# Altium schematic sheet Sway Rev C - Sheet 1.SchDoc("Sway Rev C - Sheet 1")
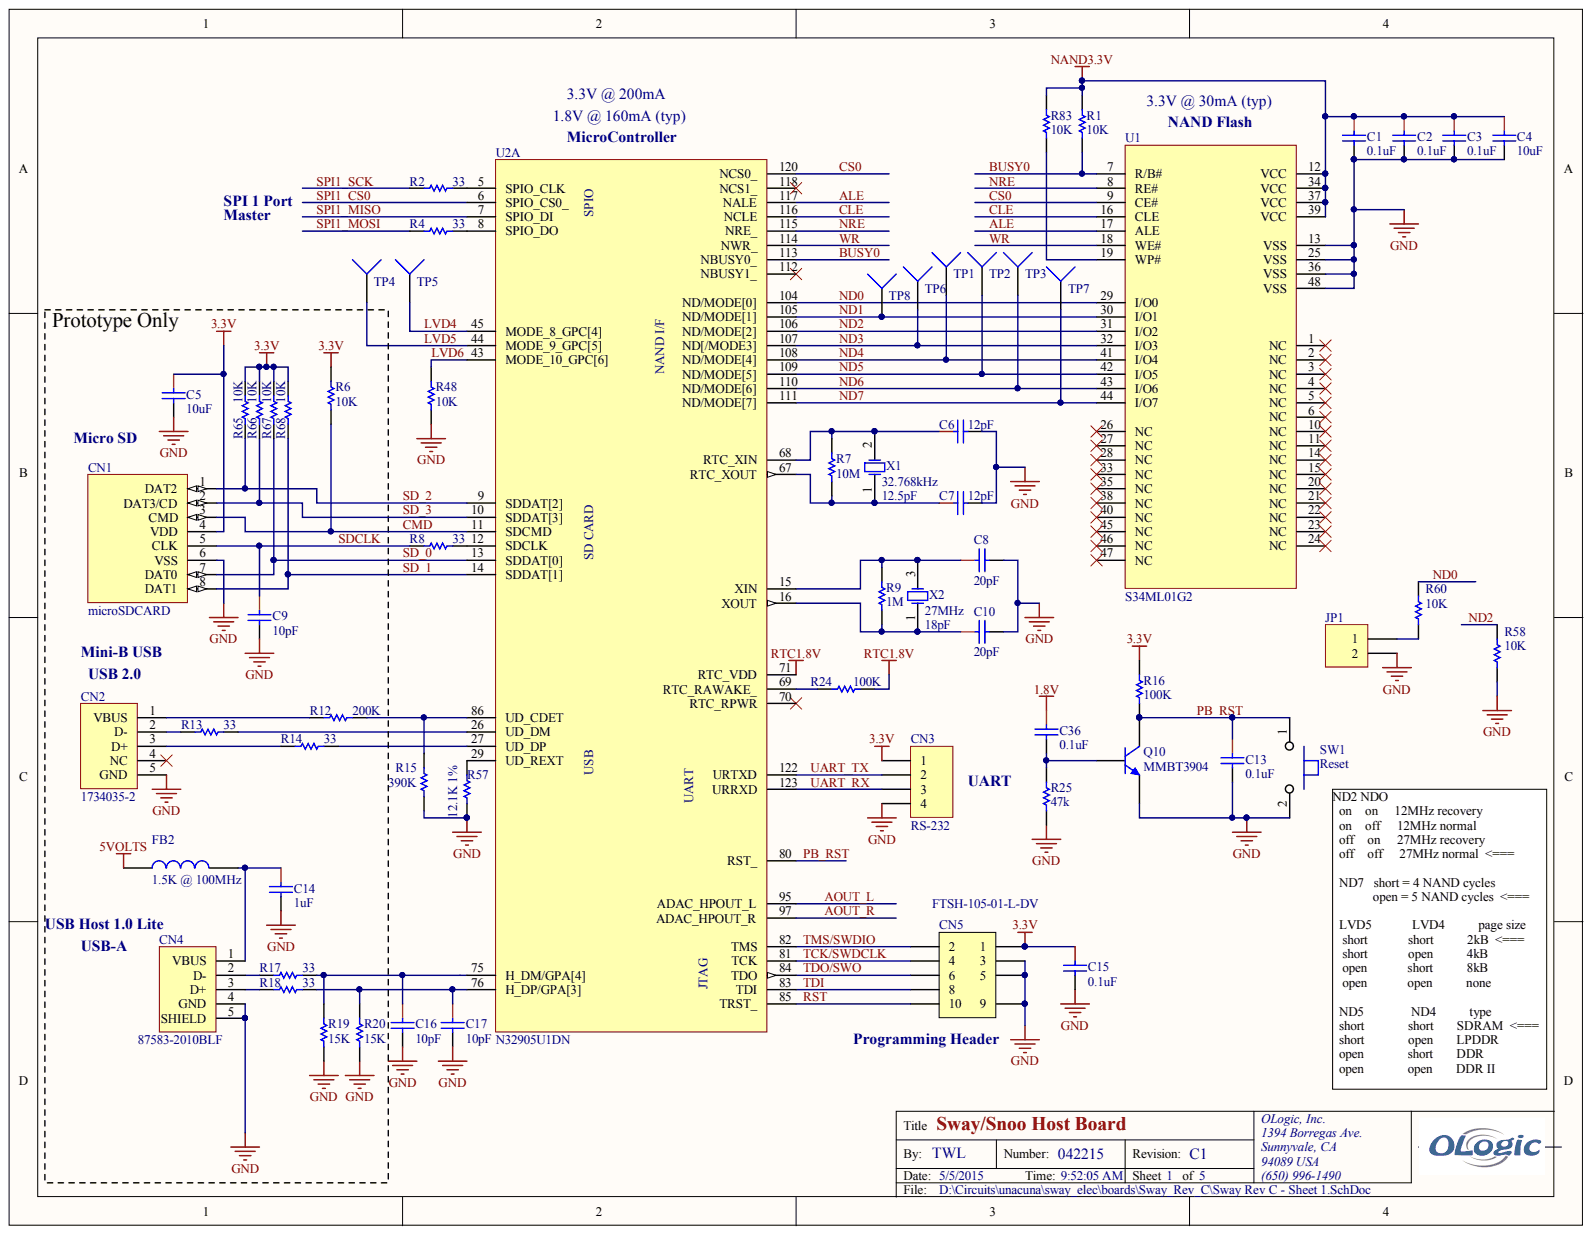
[diagram p0: Sway Rev C - Sheet 1.SchDoc]

## Parts
C1  0.1uF  [CAPC0603(1608)_L]
C2  0.1uF  [CAPC0603(1608)_L]
C3  0.1uF  [CAPC0603(1608)_L]
C4  10uF  [CAPC0805(2012)_L]
C5  10uF  [CAPC0805(2012)_L]
C6  12pF  [CAPC0603(1608)_L]
C7  12pF  [CAPC0603(1608)_L]
C8  20pF  [CAPC0603(1608)_L]
C9  10pF  [CAPC0603(1608)_L]
C10  20pF  [CAPC0603(1608)_L]
C13  0.1uF  [CAPC0603(1608)_L]
C14  1uF  [CAPC0603(1608)_L]
C15  0.1uF  [CAPC0603(1608)_L]
C16  10pF  [CAPC0603(1608)_L]
C17  10pF  [CAPC0603(1608)_L]
C36  0.1uF  [CAPC0603(1608)_L]
CN1  microSDCARD  [MicroSD]
CN2  1734035-2  Specifications: USB 2.0  [USB-MINI-B]
CN3  RS-232  [HDR1X4]
CN4  87583-2010BLF  [87583-2010]
CN5  Manufacturer Part Number: FTSH-105-01-L-DV  [HDR_2x5-SMD-1.27mm-SWD]  (hidden comment: Conn-2x5)
FB2  1.5K @ 100MHz  [RESC0603(1608)_L]
JP1  PGM  [HDR1X2]  (value not drawn)
Q10  MMBT3904  [SOT23]
R1  10K  [RESC0603(1608)_L]
R2  33  [RESC0603(1608)_L]
R4  33  [RESC0603(1608)_L]
R6  10K  [RESC0603(1608)_L]
R7  10M  [RESC0603(1608)_L]
R8  33  [RESC0603(1608)_L]
R9  1M  [RESC0603(1608)_L]
R12  200K  [RESC0603(1608)_L]
R13  33  [RESC0603(1608)_L]
R14  33  [RESC0603(1608)_L]
R15  390K  [RESC0603(1608)_L]
R16  100K  [RESC0603(1608)_L]
R17  33  [RESC0603(1608)_L]
R18  33  [RESC0603(1608)_L]
R19  15K  [RESC0603(1608)_L]
R20  15K  [RESC0603(1608)_L]
R24  100K  [RESC0603(1608)_L]
R25  47k  [RESC0603(1608)_N]
R48  10K  [RESC0603(1608)_L]
R57  12.1K 1%  [RESC0603(1608)_L]
R58  10K  [RESC0603(1608)_L]
R60  10K  [RESC0603(1608)_L]
R65  10K  [RESC0603(1608)_L]
R66  10K  [RESC0603(1608)_L]
R67  10K  [RESC0603(1608)_L]
R68  10K  [RESC0603(1608)_L]
R83  10K  [RESC0603(1608)_L]
SW1  Reset  [EVPA-PushButton]
TP1  Test Point  [TestPoint-SM]  (value not drawn)
TP2  Test Point  [TestPoint-SM]  (value not drawn)
TP3  Test Point  [TestPoint-SM]  (value not drawn)
TP4  Test Point  [TestPoint-SM]  (value not drawn)
TP5  Test Point  [TestPoint-SM]  (value not drawn)
TP6  Test Point  [TestPoint-SM]  (value not drawn)
TP7  Test Point  [TestPoint-SM]  (value not drawn)
TP8  Test Point  [TestPoint-SM]  (value not drawn)
U1  S34ML01G2  [TSOP48]
U2  N32905U1DN  [LQFP128]  (part A)
X1  32.768kHz  Load Capacitance: 12.5pF  [FC-135]
X2  27MHz  Load Capacitance: 18pF  [SMOSC/6x3.6]

## Nets
1.8V: C36.1
3.3V: C5.1 C15.1 CN1.4(VDD) CN3.1 CN5.1 R6.2 R16.2 R65.2 R66.2 R67.2 R68.2
5VOLTS: FB2.1
GND: C1.2 C2.2 C3.2 C4.2 C5.2 C6.2 C7.2 C8.2 C9.2 C10.2 C13.2 C14.2 C15.2 C16.2 C17.2 CN1.6(VSS) CN2.5(GND) CN3.4 CN4.4(GND) CN4.5(SHIELD) CN5.3 CN5.5 CN5.9 JP1.2 Q10.2 R15.1 R19.1 R20.1 R25.1 R48.1 R57.1 R58.1 SW1.2 U1.13(VSS) U1.25(VSS) U1.36(VSS) U1.48(VSS)
NAND3.3V: C1.1 C2.1 C3.1 C4.1 R1.2 R83.2 U1.12(VCC) U1.34(VCC) U1.37(VCC) U1.39(VCC)
RTC1.8V: R24.1 U2.71(RTC_VDD)
ALE: U1.17(ALE) U2.117(NALE)
AOUT_L: U2.95(ADAC_HPOUT_L)
AOUT_R: U2.97(ADAC_HPOUT_R)
BUSY0: R1.1 U1.7(R/B#) U2.113(NBUSY0_)
CLE: U1.16(CLE) U2.116(NCLE)
CMD: CN1.3(CMD) R6.1 U2.11(SDCMD)
CS0: U1.9(CE#) U2.120(NCS0_)
LVD4: TP5.1 U2.45(MODE_8_GPC[4])
LVD5: TP4.1 U2.44(MODE_9_GPC[5])
LVD6: R48.2 U2.43(MODE_10_GPC[6])
ND0: R60.2 U1.29(I/O0) U2.104(ND/MODE[0])
ND1: TP8.1 U1.30(I/O1) U2.105(ND/MODE[1])
ND2: R58.2 U1.31(I/O2) U2.106(ND/MODE[2])
ND3: TP6.1 U1.32(I/O3) U2.107(ND[/MODE3])
ND4: TP1.1 U1.41(I/O4) U2.108(ND/MODE[4])
ND5: TP2.1 U1.42(I/O5) U2.109(ND/MODE[5])
ND6: TP3.1 U1.43(I/O6) U2.110(ND/MODE[6])
ND7: TP7.1 U1.44(I/O7) U2.111(ND/MODE[7])
NRE: U1.8(RE#) U2.115(NRE_)
PB_RST: C13.1 Q10.3 R16.1 SW1.1 U2.80(RST_)
RST: CN5.10 U2.85(TRST_)
SD_0: CN1.7(DAT0) R67.1 U2.13(SDDAT[0])
SD_1: CN1.8(DAT1) R68.1 U2.14(SDDAT[1])
SD_2: CN1.1(DAT2) R65.1 U2.9(SDDAT[2])
SD_3: CN1.2(DAT3/CD) R66.1 U2.10(SDDAT[3])
SDCLK: C9.1 CN1.5(CLK) R8.2
SPI1_CS0: U2.6(SPIO_CS0_)
SPI1_MISO: U2.7(SPIO_DI)
SPI1_MOSI: R4.2
SPI1_SCK: R2.2
TCK/SWDCLK: CN5.4 U2.81(TCK)
TDI: CN5.8 U2.83(TDI)
TDO/SWO: CN5.6 U2.84(TDO)
TMS/SWDIO: CN5.2 U2.82(TMS)
UART_RX: CN3.3 U2.123(URRXD)
UART_TX: CN3.2 U2.122(URTXD)
WR: U1.18(WE#) U2.114(NWR_)
NetC6_1: C6.1 R7.2 U2.68(RTC_XIN) X1.2
NetC7_1: C7.1 R7.1 U2.67(RTC_XOUT) X1.1
NetC8_1: C8.1 R9.2 U2.15(XIN) X2.3
NetC10_1: C10.1 R9.1 U2.16(XOUT) X2.1
NetC14_1: C14.1 CN4.1(VBUS) FB2.2
NetC16_1: C16.1 R17.1 R19.2 U2.75(H_DM/GPA[4])
NetC17_1: C17.1 R18.1 R20.2 U2.76(H_DP/GPA[3])
NetC36_2: C36.2 Q10.1 R25.2
NetCN2_1: CN2.1(VBUS) R12.2
NetCN2_2: CN2.2(D-) R13.2
NetCN2_3: CN2.3(D+) R14.2
NetCN4_2: CN4.2(D-) R17.2
NetCN4_3: CN4.3(D+) R18.2
NetJP1_1: JP1.1 R60.1
NetR2_1: R2.1 U2.5(SPIO_CLK)
NetR4_1: R4.1 U2.8(SPIO_DO)
NetR8_1: R8.1 U2.12(SDCLK)
NetR12_1: R12.1 R15.2 U2.86(UD_CDET)
NetR13_1: R13.1 U2.26(UD_DM)
NetR14_1: R14.1 U2.27(UD_DP)
NetR24_2: R24.2 U2.69(RTC_RAWAKE_)
NetR57_2: R57.2 U2.29(UD_REXT)
NetR83_1: R83.1 U1.19(WP#)

Not connected: CN2 4(NC); U1 1(NC) 2(NC) 3(NC) 4(NC) 5(NC) 6(NC) 10(NC) 11(NC) 14(NC) 15(NC) 20(NC) 21(NC) 22(NC) 23(NC) 24(NC) 26(NC) 27(NC) 28(NC) 33(NC) 35(NC) 38(NC) 40(NC) 45(NC) 46(NC) 47(NC); U2 70(RTC_RPWR) 112(NBUSY1_) 118(NCS1_)

## Library data of the parts
C1-C3 C13 C36 = CAP CER 0.1UF 16V 10% X7R 0603: 0.1uF 0603; Manufacturer: Kemet; Manufacturer Part Number: C0603C104K4RACTU; Capacitance: 0.1µF; Temperature Coefficient: X7R; Tolerance: ±10%; Voltage - Rated: 16V; Applications: General Purpose; Category: Capacitors; ClassName: UP; Component Kind: Standard; ComponentLink1Description: http://www.kemet.com/datasheets&C0603C104K4RACTU; ComponentLink1URL: http://www.kemet.com/datasheets&C0603C104K4RACTU; Features: -; Height - Seated (Max): -; Lead Spacing: -; Lead Style: -; Library Name: OLogic-Passive.IntLib; Mounting Type: Surface Mount, MLCC; Operating Temperature: -55°C ~ 125°C; Package / Case: 0603 (1608 Metric); Packaging: Tape & Reel (TR); Pricing 1: 4000=0.00414, 8000=0.00378, 12000=0.0036, 28000=0.00342, 100000=0.00248 (USD); Ratings: -; RoHS: RoHS Compliant; Size / Dimension: 0.063" L x 0.031" W (1.60mm x 0.80mm); Stock 1: 2360000; Supplier 1: Digi-Key; Supplier Part Number 1: 399-1096-2-ND; Thickness (Max): 0.034" (0.87mm)
C4 C5 = CAP CER 10UF 10V Y5V 0805: 10uF 0805; Manufacturer: Taiyo Yuden; Manufacturer Part Number: LMK212F106ZG-T; Capacitance: 10µF; Temperature Coefficient: Y5V (F); Tolerance: -20%, +80%; Voltage - Rated: 10V; Applications: General Purpose; Category: Capacitors; Component Kind: Standard; ComponentLink1Description: http://www.yuden.co.jp/or/product/category/capacitor/LMK212F106ZG-T.pdf; ComponentLink1URL: http://www.yuden.co.jp/or/product/category/capacitor/LMK212F106ZG-T.pdf; Features: -; Height - Seated (Max): -; Lead Spacing: -; Lead Style: -; Library Name: OLogic-Passive.IntLib; Mounting Type: Surface Mount, MLCC; Operating Temperature: -30°C ~ 85°C; Package / Case: 0805 (2012 Metric); Packaging: Cut Tape (CT); Pricing 1: 1=0.17, 10=0.115, 50=0.0662, 100=0.0546, 250=0.046, 500=0.03852, 1000=0.03163 (USD); Ratings: -; RoHS: RoHS Compliant; Size / Dimension: 0.079" L x 0.049" W (2.00mm x 1.25mm); Stock 1: 194456; Supplier 1: Digi-Key; Supplier Part Number 1: 587-1312-1-ND; Thickness (Max): 0.053" (1.35mm)
  C4: ClassName: UP
  C5: ClassName: uSDCard
C6 C7 = CAP CER 12PF 50V 5% NP0 0603: 12pF 0603 50V; Manufacturer: TDK Corporation; Manufacturer Part Number: C1608C0G1H120J080AA; Temperature Coefficient: C0G, NP0; Tolerance: ±5%; Applications: General Purpose; Capacitance: 12pF; Category: Capacitors; Component Kind: Standard; ComponentLink1Description: http://product.tdk.com/capacitor/mlcc/en/documents/mlcc_commercial_general_en.pdf; ComponentLink1URL: http://product.tdk.com/capacitor/mlcc/en/documents/mlcc_commercial_general_en.pdf; Features: -; Height - Seated (Max): -; Lead Spacing: -; Lead Style: -; Library Name: OLogic-Passive.IntLib; Mounting Type: Surface Mount, MLCC; Operating Temperature: -55°C ~ 125°C; Package / Case: 0603 (1608 Metric); Packaging: Cut Tape (CT); Pricing 1: 1=0.1, 10=0.028, 100=0.0129, 250=0.01056, 500=0.00904, 1000=0.0069 (USD); Ratings: -; RoHS: RoHS Compliant; Size / Dimension: 0.063" L x 0.031" W (1.60mm x 0.80mm); Stock 1: 133676; Supplier 1: Digi-Key; Supplier Part Number 1: 445-1270-1-ND; Thickness (Max): 0.037" (0.95mm); Voltage - Rated: 50V
C8 C10 = CAP CER 20PF 50V 5% NP0 0603: 20pF 0603; Manufacturer: Murata Electronics North America; Manufacturer Part Number: GRM1885C1H200JA01D; Temperature Coefficient: C0G, NP0; Tolerance: ±5%; Voltage - Rated: 50V; Applications: General Purpose; Capacitance: 20pF; Category: Capacitors; ClassName: UP; Component Kind: Standard; ComponentLink1Description: http://search.murata.co.jp/Ceramy/image/img/A01X/G101/ENG/GRM1885C1H200JA01-01.pdf; ComponentLink1URL: http://search.murata.co.jp/Ceramy/image/img/A01X/G101/ENG/GRM1885C1H200JA01-01.pdf; Features: -; Height - Seated (Max): -; Lead Spacing: -; Lead Style: -; Library Name: OLogic-Passive.IntLib; Mounting Type: Surface Mount, MLCC; Operating Temperature: -55°C ~ 125°C; Package / Case: 0603 (1608 Metric); Packaging: Cut Tape (CT); Pricing 1: 1=0.1, 10=0.045, 50=0.0246, 100=0.0208, 250=0.01704, 500=0.01456, 1000=0.01113 (USD); Ratings: -; RoHS: RoHS Compliant; Size / Dimension: 0.063" L x 0.031" W (1.60mm x 0.80mm); Stock 1: 33890; Supplier 1: Digi-Key; Supplier Part Number 1: 490-1410-1-ND; Thickness (Max): 0.035" (0.90mm)
C9 C16 C17 = CAP CER 10PF 250V 2% NP0 0603: 10pF 0603; Manufacturer: Johanson Technology Inc; Manufacturer Part Number: 251R14S100GV4T; Temperature Coefficient: C0G, NP0; Tolerance: ±2%; Voltage - Rated: 250V; Applications: RF, Microwave, High Frequency; Capacitance: 10pF; Category: Capacitors; Component Kind: Standard; ComponentLink1Description: http://www.johansontechnology.com/images/stories/catalog/JTI_CAT_2012_MLCC_HighQ.pdf; ComponentLink1URL: http://www.johansontechnology.com/images/stories/catalog/JTI_CAT_2012_MLCC_HighQ.pdf; Features: High Q, Low Loss; Height - Seated (Max): -; Lead Spacing: -; Lead Style: -; Library Name: OLogic-Passive.IntLib; Mounting Type: Surface Mount, MLCC; Operating Temperature: -55°C ~ 125°C; Package / Case: 0603 (1608 Metric); Packaging: Cut Tape (CT); Pricing 1: 1=0.5, 10=0.441, 25=0.3152, 50=0.2678, 100=0.2205, 250=0.1764, 500=0.16066, 1000=0.1449 (USD); Ratings: -; RoHS: RoHS Compliant; Size / Dimension: 0.062" L x 0.032" W (1.57mm x 0.81mm); Stock 1: 34809; Supplier 1: Digi-Key; Supplier Part Number 1: 712-1307-1-ND; Thickness (Max): 0.035" (0.89mm)
  C9: ClassName: uSDCard
  C16 C17: ClassName: USB_A
C14 = CAP CER 1UF 10V 10% X5R 0603: 1uF 0603; Manufacturer: Kemet; Manufacturer Part Number: C0603C105K8PACTU; Capacitance: 1.0µF; Temperature Coefficient: X5R; Tolerance: ±10%; Voltage - Rated: 10V; Applications: General Purpose; Category: Capacitors; ClassName: USB_A; Component Kind: Standard; ComponentLink1Description: http://www.kemet.com/datasheets&C0603C105K8PACTU; ComponentLink1URL: http://www.kemet.com/datasheets&C0603C105K8PACTU; Features: -; Height - Seated (Max): -; Lead Spacing: -; Lead Style: -; Library Name: OLogic-Passive.IntLib; Mounting Type: Surface Mount, MLCC; Operating Temperature: -55°C ~ 85°C; Package / Case: 0603 (1608 Metric); Packaging: Cut Tape (CT); Pricing 1: 1=0.1, 10=0.043, 25=0.0304, 50=0.0232, 100=0.0196, 250=0.01608, 1000=0.0105 (USD); Ratings: -; RoHS: RoHS Compliant; Size / Dimension: 0.063" L x 0.031" W (1.60mm x 0.80mm); Stock 1: 1121198; Supplier 1: Digi-Key; Supplier Part Number 1: 399-3118-1-ND; Thickness (Max): 0.035" (0.90mm)
C15 = CAP CER 0.1UF 50V 10% X7R 0603: 0.1UF 50V 0603; Manufacturer: Kemet; Manufacturer Part Number: C0603C104K5RACTU; Capacitance: 0.1µF; Temperature Coefficient: X7R; Tolerance: ±10%; Applications: General Purpose; Category: Capacitors; ClassName: UP; Component Kind: Standard; ComponentLink1Description: http://www.kemet.com/docfinder?Partnumber=C0603C104K5RACTU; ComponentLink1URL: http://www.kemet.com/docfinder?Partnumber=C0603C104K5RACTU; Features: -; Height - Seated (Max): -; Lead Spacing: -; Lead Style: -; Library Name: OLogic-Passive.IntLib; Mounting Type: Surface Mount, MLCC; Operating Temperature: -55°C ~ 125°C; Package / Case: 0603 (1608 Metric); Packaging: Cut Tape (CT); Pricing 1: 1=0.1, 10=0.041, 50=0.0222, 100=0.0187, 250=0.01532, 500=0.0131, 1000=0.0102 (USD); Ratings: -; RoHS: RoHS Compliant; Size / Dimension: 0.063" L x 0.031" W (1.60mm x 0.80mm); Stock 1: 1397942; Supplier 1: Digi-Key; Supplier Part Number 1: 399-5089-1-ND; Thickness (Max): 0.034" (0.87mm); Voltage - Rated: 50V
CN1 = SDCARD_micro: micro SD Card Slot; Manufacturer: Molex Inc; Manufacturer Part Number: 0473521001; Card Type: Secure Digital - microSD™; Connector Type: Connector and Ejector; Insertion, Removal Method: Push In, Push Out; Number of Positions: 8 (6 + 2); Category: Connectors, Interconnects; ClassName: uSDCard; Component Kind: Standard; ComponentLink1Description: http://www.molex.com/pdm_docs/sd/473521001_sd.pdf; ComponentLink1URL: http://www.molex.com/pdm_docs/sd/473521001_sd.pdf; Contact Finish: Gold; Contact Finish Thickness: -; Ejector Side: -; Features: Switch; Height Above Board: 0.074" (1.88mm); Library Name: OLogic-Connectors.IntLib; Mounting Feature: Normal, Standard - Top; Mounting Type: Surface Mount, Right Angle; Packaging: Cut Tape (CT); Pricing 1: 1=3.76, 10=3.605, 25=3.3048, 50=3.1548, 100=3.0045, 250=2.62896 (USD); RoHS: RoHS Compliant; Stock 1: 954; Supplier 1: Digi-Key; Supplier Part Number 1: WM3290CT-ND
CN2 = Mini-USB-B: Mini-USB RA Type B; Manufacturer: Tyco; Connector Type: USB - mini B; Gender: Receptacle; Number of Contacts: 5; PN: A31727CT-ND; Vendor: Digikey; Category: Connectors, Interconnects; ClassName: USB_B; Component Kind: Standard; ComponentLink1Description: http://www.te.com/commerce/DocumentDelivery/DDEController?Action=srchrtrv&DocNm=1734035&DocType=Customer+Drawing&DocLang=English; ComponentLink1URL: http://www.te.com/commerce/DocumentDelivery/DDEController?Action=srchrtrv&DocNm=1734035&DocType=Customer+Drawing&DocLang=English; Features: Board Guide; Ingress Protection: -; Library Name: OLogic-Connectors.IntLib; Manufacturer Part Number: 1734035-2; Mounting Type: Surface Mount, Right Angle, Horizontal; MPN: 1734035-2; Packaging: Cut Tape (CT); Pricing 1: 1=1.76, 10=1.598, 25=1.5004, 50=1.435, 100=1.3698, 250=1.23932 (USD); RoHS: RoHS Compliant; Stock 1: 27347; Supplier 1: Digi-Key; Supplier Part Number 1: A31727CT-ND
CN3 = Header 4: Header, 4-Pin; Manufacturer Part Number: 826936-4; Brand: TE Connectivity / AMP; Mating Post Length: 5.8 mm; Mounting Angle: Vertical; Number of Positions: 4; Pitch: 2.54 mm; Category: Headers & Wire Housings; ClassName: UP; Component Kind: Standard; ComponentLink1Description: http://www.te.com/catalog/pn/en/826936-4; ComponentLink1URL: http://www.te.com/catalog/pn/en/826936-4; Contact Gender: Pin (Male); Contact Material: Brass; Contact Plating: Tin; Flammability Rating: UL 94 V-0; Housing Material: Thermoplastic; Library Name: OLogic-Connectors.IntLib; Manufacturer: TE Connectivity / AMP; Minimum Order Quantity: 1; Mounting Style: -; Number of Rows: 1; Pricing 1: 1=0.250, 25=0.239, 50=0.233, 100=0.216, 250=0.206, 500=0.178, 1000=0.160, 2000=0.154, 5000=0.146 (USD); Product Category: AMPMODU Breakaway Headers; Product Type: Headers; Reeling: False; RoHS: RoHS Compliant; RoHS - Mouser: Y; Row Spacing: 2.54 mm; Series: AMPMODU MOD II; Signal Integrity: Connector; Standard Pack Qty: 1500; Stock 1: 1165; Supplier 1: Mouser; Supplier Part Number 1: 571-826936-4; Termination Post Length: 3.2 mm; Termination Style: Through Hole; Tradename: AMPMODU; Type: Breakaway
CN4 = FCI-87583-2010: USB-A Receptacle; Manufacturer: FCI; Number of Contacts: 4; PN: 609-4413-ND; Specifications: USB 2.0; Vendor: Digikey; Category: Connectors, Interconnects; Component Kind: Standard; ComponentLink1Description: http://portal.fciconnect.com/Comergent//fci/drawing/87583.pdf; ComponentLink1URL: http://portal.fciconnect.com/Comergent//fci/drawing/87583.pdf; Connector Type: USB - A; Features: Board Lock; Gender: Receptacle; Ingress Protection: -; Library Name: OLogic-Connectors.IntLib; Manufacturer Part Number: 87583-2010BLF; Mounting Type: Surface Mount, Right Angle, Horizontal; MPN: 87583-2010BLF; Packaging: Tube; Pricing 1: 1=0.89, 10=0.78, 25=0.7344, 50=0.7038, 100=0.6732, 250=0.612, 500=0.5508, 1000=0.4896, 2500=0.4437 (USD); RoHS: RoHS Compliant; Stock 1: 25474; Supplier 1: Digi-Key; Supplier Part Number 1: 609-4413-ND
CN5 = CONN-2x5-SWD: SAMTEC - FTSH-105-01-L-DV - HEADER, 1.27MM, SMD, 10WAY; Connector Type: Header, Unshrouded; Number of Positions: 10; Number of Positions Loaded: All; Pitch: 0.050" (1.27mm); PN: SAM1161-05-ND; Vendor: Digikey; Category: Connectors, Interconnects; ClassName: UP; Color: Black; Component Kind: Standard; ComponentLink1Description: http://www.samtec.com/documents/webfiles/cpdf/FTSH-1XX-XX-XXX-DV-XXX-MKT.pdf; ComponentLink1URL: http://www.samtec.com/documents/webfiles/cpdf/FTSH-1XX-XX-XXX-DV-XXX-MKT.pdf; Contact Finish: Gold; Contact Finish Thickness: 10µin (0.25µm); Contact Mating Length: 0.120" (3.05mm); Contact Type: Male Pin; Fastening Type: -; Features: -; Library Name: OLogic-Connectors.IntLib; Manufacturer: Samtec; Mounting Type: Surface Mount; MPN: FTSH-105-01-L-DV; Number of Rows: 2; Packaging: Bulk; Pricing 1: 1=3.42, 10=2.963, 100=2.5073, 500=1.99444, 1000=1.62404, 5000=1.48158, 10000=1.4246 (USD); RoHS: RoHS Compliant; Row Spacing: 0.050" (1.27mm); Stock 1: 0; Supplier 1: Digi-Key; Supplier Part Number 1: SAM1161-05-ND; Termination: Solder
FB2 = FERRITE CHIP 1500 OHMS 100MA 0603: 1.5K 0603 1500 100mA; Manufacturer: Laird-Signal Integrity Products; Manufacturer Part Number: HZ0603A152R-10; DC Resistance (DCR): 900 mOhm Max; Filter Type: Differential Mode - Single; Impedance @ Frequency: 1.5 kOhm @ 100MHz; Category: Filters; ClassName: USB_A; Component Kind: Standard; ComponentLink1Description: http://www.lairdtech.com/WorkArea/linkit.aspx?LinkIdentifier=id&ItemID=4872; ComponentLink1URL: http://www.lairdtech.com/WorkArea/linkit.aspx?LinkIdentifier=id&ItemID=4872; Current Rating: 100mA; Height (Max): 0.037" (0.95mm); Library Name: OLogic-Passive.IntLib; Mounting Type: Surface Mount; Package / Case: 0603 (1608 Metric); Packaging: Cut Tape (CT); Pricing 1: 1=0.1, 10=0.07, 25=0.0632, 50=0.057, 100=0.0417, 250=0.03036, 500=0.02784, 1000=0.02404 (USD); RoHS: RoHS Compliant; Size / Dimension: 0.063" L x 0.031" W (1.60mm x 0.80mm); Stock 1: 52189; Supplier 1: Digi-Key; Supplier Part Number 1: 240-2559-1-ND
JP1 = Header 2: Header, 2-Pin; Manufacturer Part Number: 826936-2; Brand: TE Connectivity; Mating Post Length: 5.8 mm; Mounting Angle: Vertical; Number of Positions: 2; Pitch: 2.54 mm; Category: Headers & Wire Housings; Component Kind: Standard; ComponentLink1Description: http://www.te.com/catalog/pn/en/826936-2; ComponentLink1URL: http://www.te.com/catalog/pn/en/826936-2; Contact Gender: Pin (Male); Contact Material: Brass; Contact Plating: Tin; Flammability Rating: UL 94 V-0; Housing Material: Thermoplastic; LatestRevisionNote: Re-released for DXP Platform.; Library Name: OLogic-Connectors.IntLib; Manufacturer: TE Connectivity; Minimum Order Quantity: 1; Mounting Style: -; Number of Rows: 1; Pricing 1: 1=0.100, 25=0.084, 50=0.080, 100=0.077, 500=0.070, 1000=0.067 (USD); Product Category: AMPMODU Breakaway Headers; Product Type: Headers; Reeling: False; RoHS: RoHS Compliant; RoHS - Mouser: Y; Row Spacing: 2.54 mm; Series: AMPMODU MOD II; Signal Integrity: Connector; Standard Pack Qty: 3000; Stock 1: 16740; Supplier 1: Mouser; Supplier Part Number 1: 571-826936-2; Termination Post Length: 3.2 mm; Termination Style: Through Hole; Tradename: AMPMODU; Type: Breakaway; Publisher: Altium Limited
Q10 = MMBT3904: NPN General Purpose Amplifier; Manufacturer: ON Semiconductor; Manufacturer Part Number: MMBT3904WT1G; Current - Collector (Ic) (Max): 200mA; DC Current Gain (hFE) (Min) @ Ic, Vce: 100 @ 10mA, 1V; Frequency - Transition: 300MHz; PN: MMBT3904WT1GOSCT-ND; Power - Max: 150mW; Vce Saturation (Max) @ Ib, Ic: 300mV @ 5mA, 50mA; Vendor: Digikey; Voltage - Collector Emitter Breakdown (Max): 40V; Category: Discrete Semiconductor Products; Component Kind: Standard; ComponentLink1Description: http://www.onsemi.com/pub_link/Collateral/MMBT3904WT1-D.PDF; ComponentLink1URL: http://www.onsemi.com/pub_link/Collateral/MMBT3904WT1-D.PDF; Current - Collector Cutoff (Max): -; Library Name: OLogic-Trans-Drivers.IntLib; Mounting Type: Surface Mount; MPN: MMBT3904WT1G; Package / Case: SC-70, SOT-323; Packaging: Cut Tape (CT); Pricing 1: 1=0.17, 10=0.154, 25=0.1404, 100=0.101, 250=0.05952, 500=0.04938, 1000=0.03368 (USD); RoHS: RoHS Compliant; Stock 1: 3; Supplier 1: Digi-Key; Supplier Device Package: SC-70-3 (SOT323); Supplier Part Number 1: MMBT3904WT1GOSCT-ND; Transistor Type: NPN
R1 R6 R48 R58 R60 R65-R68 R83 = RES 10K OHM 1/10W 5% 0603 SMD: 10K 0603; Manufacturer: Panasonic Electronic Components; Manufacturer Part Number: ERJ-3GEYJ103V; Power (Watts): 0.1W, 1/10W; Temperature Coefficient: ±200ppm/°C; Tolerance: ±5%; Category: Resistors; Component Kind: Standard; ComponentLink1Description: http://industrial.panasonic.com/www-cgi/jvcr13pz.cgi?E+PZ+3+AOA0001+ERJ3GEYJ103V+7+WW; ComponentLink1URL: http://industrial.panasonic.com/www-cgi/jvcr13pz.cgi?E+PZ+3+AOA0001+ERJ3GEYJ103V+7+WW; Composition: Thick Film; Features: -; Height: 0.022" (0.55mm); Library Name: OLogic-Passive.IntLib; Number of Terminations: 2; Package / Case: 0603 (1608 Metric); Packaging: Cut Tape (CT); Pricing 1: 1=0.1, 50=0.0126, 100=0.0096, 250=0.00716, 500=0.00528, 1000=0.00352, 2500=0.00297 (USD); Resistance (Ohms): 10k; RoHS: RoHS Compliant; Signal Integrity: Res; Simulation: RESISTOR; Size / Dimension: 0.063" L x 0.031" W (1.60mm x 0.80mm); Stock 1: 4724855; Supplier 1: Digi-Key; Supplier Device Package: 0603; Supplier Part Number 1: P10KGCT-ND
  R1 R48 R58 R60: ClassName: UP
  R6 R65-R68: ClassName: uSDCard
R2 R4 R8 R13 R14 R17 R18 = RES 33 OHM 1/10W 5% 0603 SMD: 33 OHM 0603; Manufacturer: Panasonic Electronic Components; Manufacturer Part Number: ERJ-3GEYJ330V; Power (Watts): 0.1W, 1/10W; Temperature Coefficient: ±200ppm/°C; Tolerance: ±5%; Category: Resistors; Component Kind: Standard; ComponentLink1Description: http://industrial.panasonic.com/www-cgi/jvcr13pz.cgi?E+PZ+3+AOA0001+ERJ3GEYJ330V+7+WW; ComponentLink1URL: http://industrial.panasonic.com/www-cgi/jvcr13pz.cgi?E+PZ+3+AOA0001+ERJ3GEYJ330V+7+WW; Composition: Thick Film; Features: -; Height: 0.022" (0.55mm); Library Name: OLogic-Passive.IntLib; Number of Terminations: 2; Package / Case: 0603 (1608 Metric); Packaging: Cut Tape (CT); Pricing 1: 1=0.1, 50=0.0126, 100=0.0096, 250=0.00716, 500=0.00528, 1000=0.00352, 2500=0.00297 (USD); Resistance (Ohms): 33; RoHS: RoHS Compliant; Simulation: RESISTOR; Size / Dimension: 0.063" L x 0.031" W (1.60mm x 0.80mm); Stock 1: 499360; Supplier 1: Digi-Key; Supplier Device Package: 0603; Supplier Part Number 1: P33GCT-ND
  R8: ClassName: uSDCard
  R17 R18: ClassName: USB_A
R7 = RES 10M OHM 1/10W 5% 0603 SMD: 10M 0603 5%; Manufacturer: Panasonic Electronic Components; Manufacturer Part Number: ERJ-3GEYJ106V; Power (Watts): 0.1W, 1/10W; Temperature Coefficient: -400/ +150ppm/°C; Category: Resistors; ClassName: UP; Component Kind: Standard; ComponentLink1Description: http://industrial.panasonic.com/www-cgi/jvcr13pz.cgi?E+PZ+3+AOA0001+ERJ3GEYJ106V+7+WW; ComponentLink1URL: http://industrial.panasonic.com/www-cgi/jvcr13pz.cgi?E+PZ+3+AOA0001+ERJ3GEYJ106V+7+WW; Composition: Thick Film; Features: -; Height: 0.022" (0.55mm); Library Name: OLogic-Passive.IntLib; Number of Terminations: 2; Package / Case: 0603 (1608 Metric); Packaging: Cut Tape (CT); Pricing 1: 1=0.1, 50=0.0126, 100=0.0096, 250=0.00716, 500=0.00528, 1000=0.00352, 2500=0.00297 (USD); Resistance (Ohms): 10M; RoHS: RoHS Compliant; Signal Integrity: Res; Simulation: RESISTOR; Size / Dimension: 0.063" L x 0.031" W (1.60mm x 0.80mm); Stock 1: 123026; Supplier 1: Digi-Key; Supplier Device Package: 0603; Supplier Part Number 1: P10MGCT-ND; Tolerance: ±5%
R9 = RES 1M OHM 1/10W 1% 0603 SMD: 1M 1% 0603; Manufacturer: Panasonic Electronic Components; Manufacturer Part Number: ERJ-3EKF1004V; Power (Watts): 0.1W, 1/10W; Temperature Coefficient: ±100ppm/°C; Category: Resistors; ClassName: UP; Component Kind: Standard; ComponentLink1Description: http://industrial.panasonic.com/www-cgi/jvcr13pz.cgi?E+PZ+3+AOA0002+ERJ3EKF1004V+7+WW; ComponentLink1URL: http://industrial.panasonic.com/www-cgi/jvcr13pz.cgi?E+PZ+3+AOA0002+ERJ3EKF1004V+7+WW; Composition: Thick Film; Features: -; Height: 0.022" (0.55mm); Library Name: OLogic-Passive.IntLib; Number of Terminations: 2; Package / Case: 0603 (1608 Metric); Packaging: Cut Tape (CT); Pricing 1: 1=0.1, 50=0.015, 100=0.0114, 250=0.00844, 500=0.00624, 1000=0.00416, 2500=0.00351 (USD); Resistance (Ohms): 1M; RoHS: RoHS Compliant; Signal Integrity: Res; Simulation: RESISTOR; Size / Dimension: 0.063" L x 0.031" W (1.60mm x 0.80mm); Stock 1: 709119; Supplier 1: Digi-Key; Supplier Device Package: 0603; Supplier Part Number 1: P1.00MHCT-ND; Tolerance: ±1%
R12 = RES 200K OHM 1/10W 5% 0603 SMD: 200K 0603 5%; Manufacturer: Panasonic Electronic Components; Manufacturer Part Number: ERJ-3GEYJ204V; Power (Watts): 0.1W, 1/10W; Temperature Coefficient: ±200ppm/°C; Category: Resistors; ClassName: USB_B; Component Kind: Standard; ComponentLink1Description: http://industrial.panasonic.com/www-cgi/jvcr13pz.cgi?E+PZ+3+AOA0001+ERJ3GEYJ204V+7+WW; ComponentLink1URL: http://industrial.panasonic.com/www-cgi/jvcr13pz.cgi?E+PZ+3+AOA0001+ERJ3GEYJ204V+7+WW; Composition: Thick Film; Features: -; Height: 0.022" (0.55mm); Library Name: OLogic-Passive.IntLib; Number of Terminations: 2; Package / Case: 0603 (1608 Metric); Packaging: Cut Tape (CT); Pricing 1: 1=0.1, 50=0.0126, 100=0.0096, 250=0.00716, 500=0.00528, 1000=0.00352, 2500=0.00297 (USD); Resistance (Ohms): 200k; RoHS: RoHS Compliant; Signal Integrity: Res; Simulation: RESISTOR; Size / Dimension: 0.063" L x 0.031" W (1.60mm x 0.80mm); Stock 1: 156206; Supplier 1: Digi-Key; Supplier Device Package: 0603; Supplier Part Number 1: P200KGCT-ND; Tolerance: ±5%
R15 = RES 390K OHM 1/10W 5% 0603 SMD: 390K 0603 5%; Manufacturer: Panasonic Electronic Components; Manufacturer Part Number: ERJ-3GEYJ394V; Power (Watts): 0.1W, 1/10W; Temperature Coefficient: ±200ppm/°C; Category: Resistors; ClassName: USB_B; Component Kind: Standard; ComponentLink1Description: http://industrial.panasonic.com/www-cgi/jvcr13pz.cgi?E+PZ+3+AOA0001+ERJ3GEYJ394V+7+WW; ComponentLink1URL: http://industrial.panasonic.com/www-cgi/jvcr13pz.cgi?E+PZ+3+AOA0001+ERJ3GEYJ394V+7+WW; Composition: Thick Film; Features: -; Height: 0.022" (0.55mm); Library Name: OLogic-Passive.IntLib; Number of Terminations: 2; Package / Case: 0603 (1608 Metric); Packaging: Cut Tape (CT); Pricing 1: 1=0.1, 50=0.0126, 100=0.0096, 250=0.00716, 500=0.00528, 1000=0.00352, 2500=0.00297 (USD); Resistance (Ohms): 390k; RoHS: RoHS Compliant; Signal Integrity: Res; Simulation: RESISTOR; Size / Dimension: 0.063" L x 0.031" W (1.60mm x 0.80mm); Stock 1: 77700; Supplier 1: Digi-Key; Supplier Device Package: 0603; Supplier Part Number 1: P390KGCT-ND; Tolerance: ±5%
R16 R24 = RES 100K OHM 1/10W 5% 0603 SMD: 100K 0603; Manufacturer: Panasonic Electronic Components; Manufacturer Part Number: ERJ-3GEYJ104V; Power (Watts): 0.1W, 1/10W; Temperature Coefficient: ±200ppm/°C; Tolerance: ±5%; Category: Resistors; Component Kind: Standard; ComponentLink1Description: http://industrial.panasonic.com/www-cgi/jvcr13pz.cgi?E+PZ+3+AOA0001+ERJ3GEYJ104V+7+WW; ComponentLink1URL: http://industrial.panasonic.com/www-cgi/jvcr13pz.cgi?E+PZ+3+AOA0001+ERJ3GEYJ104V+7+WW; Composition: Thick Film; Features: -; Height: 0.022" (0.55mm); Library Name: OLogic-Passive.IntLib; Number of Terminations: 2; Package / Case: 0603 (1608 Metric); Packaging: Cut Tape (CT); Pricing 1: 1=0.1, 50=0.0126, 100=0.0096, 250=0.00716, 500=0.00528, 1000=0.00352, 2500=0.00297 (USD); Resistance (Ohms): 100k; RoHS: RoHS Compliant; Signal Integrity: Res; Simulation: RESISTOR; Size / Dimension: 0.063" L x 0.031" W (1.60mm x 0.80mm); Stock 1: 884549; Supplier 1: Digi-Key; Supplier Device Package: 0603; Supplier Part Number 1: P100KGCT-ND
  R16: ClassName: UP
R19 R20 = RES 15K OHM 1/10W 5% 0603 SMD: 15K 0603 5%; Manufacturer: Panasonic Electronic Components; Manufacturer Part Number: ERJ-3GEYJ153V; Power (Watts): 0.1W, 1/10W; Temperature Coefficient: ±200ppm/°C; Category: Resistors; ClassName: USB_A; Component Kind: Standard; ComponentLink1Description: http://industrial.panasonic.com/www-cgi/jvcr13pz.cgi?E+PZ+3+AOA0001+ERJ3GEYJ153V+7+WW; ComponentLink1URL: http://industrial.panasonic.com/www-cgi/jvcr13pz.cgi?E+PZ+3+AOA0001+ERJ3GEYJ153V+7+WW; Composition: Thick Film; Features: -; Height: 0.022" (0.55mm); Library Name: OLogic-Passive.IntLib; Number of Terminations: 2; Package / Case: 0603 (1608 Metric); Packaging: Cut Tape (CT); Pricing 1: 1=0.1, 50=0.0126, 100=0.0096, 250=0.00716, 500=0.00528, 1000=0.00352, 2500=0.00297 (USD); Resistance (Ohms): 15k; RoHS: RoHS Compliant; Signal Integrity: Res; Simulation: RESISTOR; Size / Dimension: 0.063" L x 0.031" W (1.60mm x 0.80mm); Stock 1: 117982; Supplier 1: Digi-Key; Supplier Device Package: 0603; Supplier Part Number 1: P15KGCT-ND; Tolerance: ±5%
R25 = RES 47K OHM 1/10W 5% 0603 SMD: 47k 0603 5%; Manufacturer: Panasonic Electronic Components; Manufacturer Part Number: ERJ-3GEYJ473V; Power (Watts): 0.1W, 1/10W; Temperature Coefficient: ±200ppm/°C; Category: Resistors; Component Kind: Standard; ComponentLink1Description: http://industrial.panasonic.com/www-cgi/jvcr13pz.cgi?E+PZ+3+AOA0001+ERJ3GEYJ473V+7+WW; ComponentLink1URL: http://industrial.panasonic.com/www-cgi/jvcr13pz.cgi?E+PZ+3+AOA0001+ERJ3GEYJ473V+7+WW; Composition: Thick Film; Features: Automotive AEC-Q200 Qualified; Height: 0.022" (0.55mm); Library Name: OLogic-Passive.IntLib; Number of Terminations: 2; Package / Case: 0603 (1608 Metric); Packaging: Cut Tape (CT); Pricing 1: 1=0.1, 50=0.0126, 100=0.0096, 250=0.00716, 500=0.00528, 1000=0.00352, 2500=0.00297 (USD); Resistance (Ohms): 47k; RoHS: RoHS Compliant; Signal Integrity: Res; Simulation: RESISTOR; Size / Dimension: 0.063" L x 0.031" W (1.60mm x 0.80mm); Stock 1: 1229497; Supplier 1: Digi-Key; Supplier Device Package: 0603; Supplier Part Number 1: P47KGCT-ND; Tolerance: ±5%
R57 = RES 12.1K OHM 1/10W 1% 0603 SMD: 12.1K 0603 1%; Manufacturer: Panasonic Electronic Components; Manufacturer Part Number: ERJ-3EKF1212V; Power (Watts): 0.1W, 1/10W; Temperature Coefficient: ±100ppm/°C; Category: Resistors; ClassName: UP; Component Kind: Standard; ComponentLink1Description: http://industrial.panasonic.com/www-cgi/jvcr13pz.cgi?E+PZ+3+AOA0002+ERJ3EKF1212V+7+WW; ComponentLink1URL: http://industrial.panasonic.com/www-cgi/jvcr13pz.cgi?E+PZ+3+AOA0002+ERJ3EKF1212V+7+WW; Composition: Thick Film; Features: -; Height: 0.022" (0.55mm); Library Name: OLogic-Passive.IntLib; Number of Terminations: 2; Package / Case: 0603 (1608 Metric); Packaging: Cut Tape (CT); Pricing 1: 1=0.1, 50=0.015, 100=0.0114, 250=0.00844, 500=0.00624, 1000=0.00416, 2500=0.00351 (USD); Resistance (Ohms): 12.1k; RoHS: RoHS Compliant; Signal Integrity: Res; Simulation: RESISTOR; Size / Dimension: 0.063" L x 0.031" W (1.60mm x 0.80mm); Stock 1: 237562; Supplier 1: Digi-Key; Supplier Device Package: 0603; Supplier Part Number 1: P12.1KHCT-ND; Tolerance: ±1%
SW1 = SW-TE-FSM6JH: Reset Button; Manufacturer: TE Connectivity; Manufacturer Part Number: 1-1825910-0; Actuator Height off PCB, from Pins: 7.00mm; Actuator Material: Polyamide (PA66), Nylon 6/6; Actuator Orientation: Top Actuated; Actuator Type: Standard; Circuit: SPST-NO; Contact Rating @ Voltage: 0.05A @ 24VDC; Illumination: Non-Illuminated; Mechanical Life: 100,000 Cycles; Operating Force: 160gf; Outline: 6.00mm x 6.00mm; Switch Function: Off-Mom; Switch Travel: 0.25mm; Vendor: Digikey; Category: Switches; Component Kind: Standard; ComponentLink1Description: http://www.te.com/commerce/DocumentDelivery/DDEController?Action=srchrtrv&DocNm=1825910&DocType=Customer+Drawing&DocLang=English; ComponentLink1URL: http://www.te.com/commerce/DocumentDelivery/DDEController?Action=srchrtrv&DocNm=1825910&DocType=Customer+Drawing&DocLang=English; Features: -; Illumination Type, Color: -; Illumination Voltage (Nominal): -; Ingress Protection: -; Library Name: OLogic-Switches.IntLib; Mounting Type: Through Hole; Operating Temperature: -35°C ~ 85°C; Packaging: Bulk; Pricing 1: 1=0.12, 10=0.111, 25=0.1076, 50=0.1036, 100=0.0979, 250=0.09216, 500=0.08832, 1000=0.0672 (USD); RoHS: RoHS Compliant; Stock 1: 38756; Supplier 1: Digi-Key; Supplier Part Number 1: 450-1652-ND; Termination Style: PC Pin
TP1-TP8 = TestPoint: Component Kind: Standard; Description: Test Point; Library Name: OLogic-Misc.IntLib
U1 = S34ML01G2: NAND Flash 1Gbit  x8; Manufacturer: Spansion Inc; Manufacturer Part Number: S34ML01G200TFI000; Interface: Parallel; Memory Size: 1G (128M x 8); Memory Type: FLASH - NAND; Speed: 25ns; Voltage - Supply: 2.7 V ~ 3.6 V; Category: Integrated Circuits (ICs); ClassName: UP; Component Kind: Standard; ComponentLink1Description: http://www.spansion.com/Support/Datasheets/S34ML01G2_04G2.pdf; ComponentLink1URL: http://www.spansion.com/Support/Datasheets/S34ML01G2_04G2.pdf; Format - Memory: FLASH; Library Name: OLogic-CPU-Memory.IntLib; Operating Temperature: -40°C ~ 85°C; Package / Case: 48-TFSOP (0.724", 18.40mm Width); Packaging: Tray; Pricing 1: 1=2.05, 10=1.842, 50=1.808, 100=1.6155, 250=1.56296, 500=1.55714, 1000=1.45022, 2500=1.4364 (USD); RoHS: RoHS Compliant; Stock 1: 922; Supplier 1: Digi-Key; Supplier Device Package: 48-TSOP; Supplier Part Number 1: 1274-1060-ND
U2 = N32905U1DN: ARM926-based Media Processor; Component Kind: Standard; Library Name: OLogic-CPU-Memory.IntLib
X1 = CRYSTAL 32.768KHZ 12.5PF SMD: 32.768kHz 12.5PF SMD; Manufacturer: Epson; Manufacturer Part Number: MC-306 32.7680K-A0:ROHS; ESR (Equivalent Series Resistance): 35 kOhm; Frequency Tolerance: ±20ppm; Operating Mode: Fundamental; Category: Crystals and Oscillators; ClassName: UP; Component Kind: Standard; ComponentLink1Description: http://media.digikey.com/pdf/Data%20Sheets/Epson%20PDFs/MC-306%20,405,%20406.pdf; ComponentLink1URL: http://media.digikey.com/pdf/Data%20Sheets/Epson%20PDFs/MC-306%20,405,%20406.pdf; Frequency: 32.768kHz; Frequency Stability: -; Height: 0.100" (2.54mm); Library Name: OLogic-RF-Osc.IntLib; Mounting Type: Surface Mount; Operating Temperature: -40°C ~ 85°C; Package / Case: 4-SOJ, 5.50mm pitch; Packaging: Cut Tape (CT); Pricing 1: 1=0.85, 10=0.75, 50=0.7006, 100=0.62, 500=0.5859 (USD); Ratings: -; RoHS: RoHS Compliant; Size / Dimension: 0.315" L x 0.126" W (8.00mm x 3.20mm); Stock 1: 42459; Supplier 1: Digi-Key; Supplier Part Number 1: SER2407CT-ND; Type: kHz Crystal (Tuning Fork)
X2 = CRYSTAL 27MHZ 18PF SMD: 27MHz 18pF ABMM Parallel Load Crystal; Manufacturer: ECS Inc; Manufacturer Part Number: ECS-270-18-23A-EN-TR; ESR (Equivalent Series Resistance): 40 Ohm; Frequency Stability: ±50ppm; Frequency Tolerance: ±30ppm; Operating Mode: Fundamental; Category: Crystals and Oscillators; ClassName: UP; Component Kind: Standard; ComponentLink1Description: http://www.ecsxtal.com/store/pdf/ecx-64a_ecx-64cr.pdf; ComponentLink1URL: http://www.ecsxtal.com/store/pdf/ecx-64a_ecx-64cr.pdf; Frequency: 27MHz; Height: 0.043" (1.10mm); Library Name: OLogic-RF-Osc.IntLib; Mounting Type: Surface Mount; Operating Temperature: -40°C ~ 85°C; Package / Case: 2-SMD; Packaging: Digi-Reel®; Pricing 1: 1=1.69, 10=1.463, 50=1.2826, 100=1.0125, 500=0.855 (USD); Ratings: -; RoHS: RoHS Compliant; Size / Dimension: 0.236" L x 0.138" W (6.00mm x 3.50mm); Stock 1: 2835; Supplier 1: Digi-Key; Supplier Part Number 1: XC1672DKR-ND; Type: MHz Crystal
